# Altium schematic sheet ledbrick_pwm_top.SchDoc(ledbrick_pwm_top)
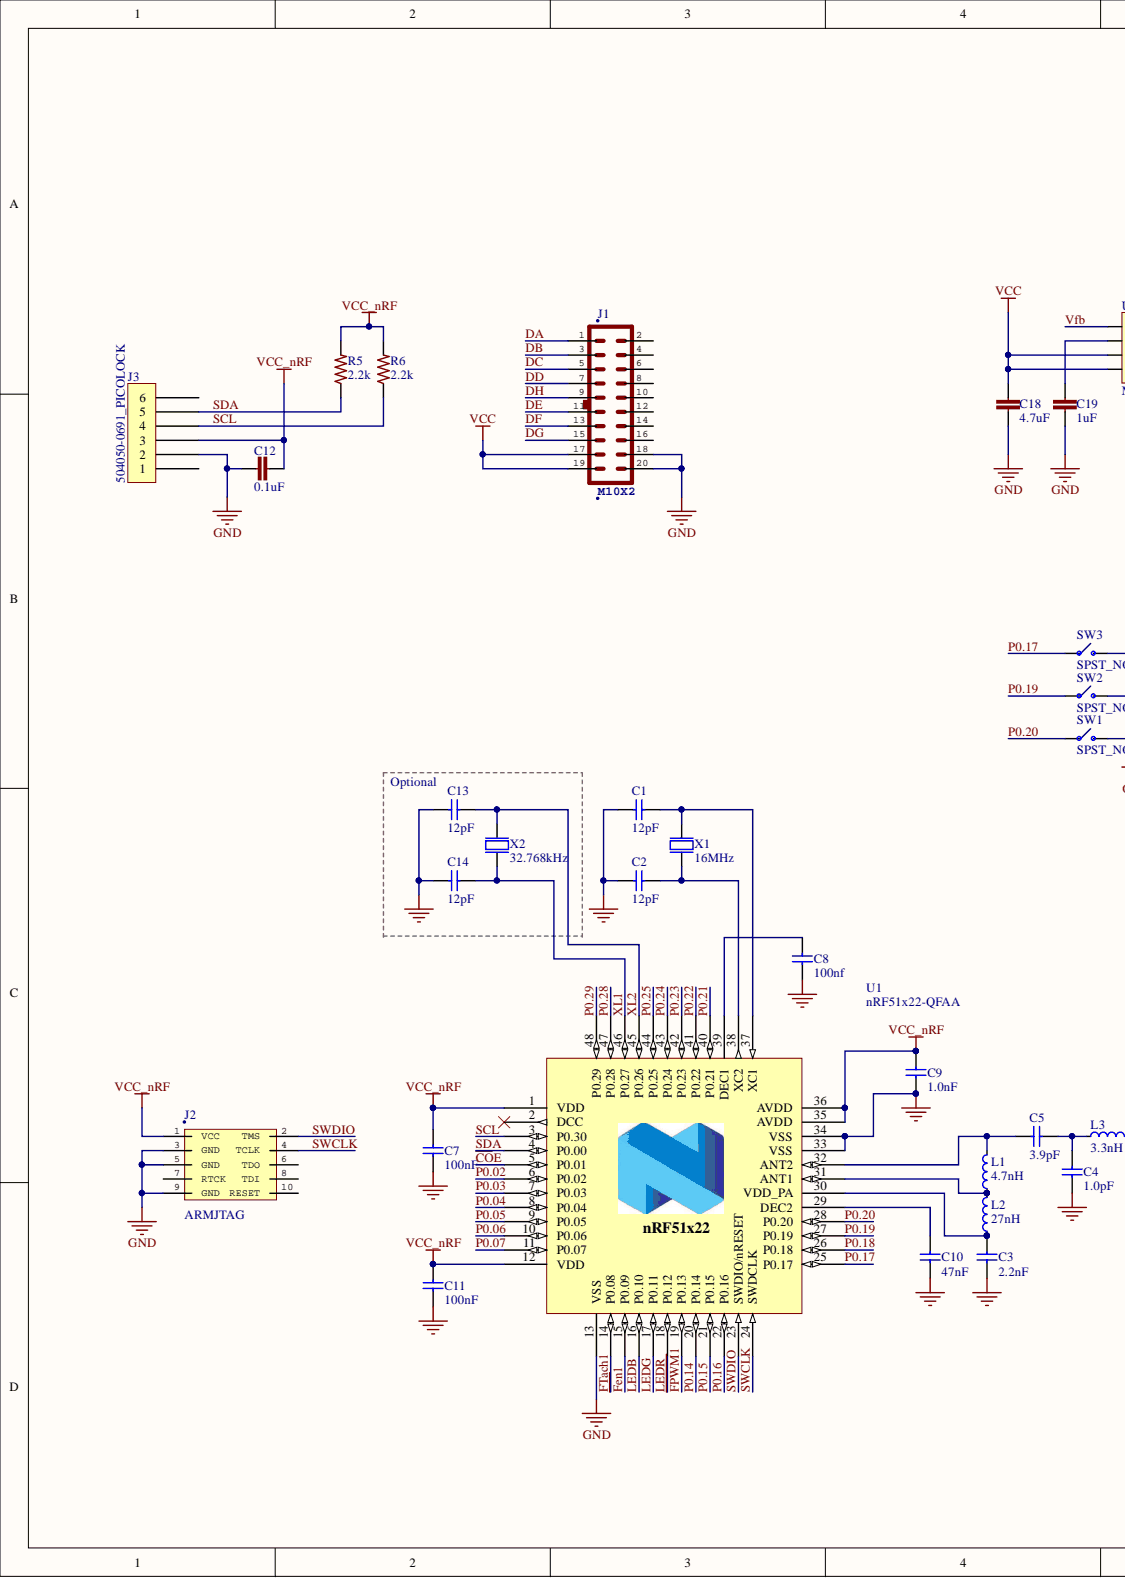
[diagram p0: ledbrick_pwm_top.SchDoc, left]
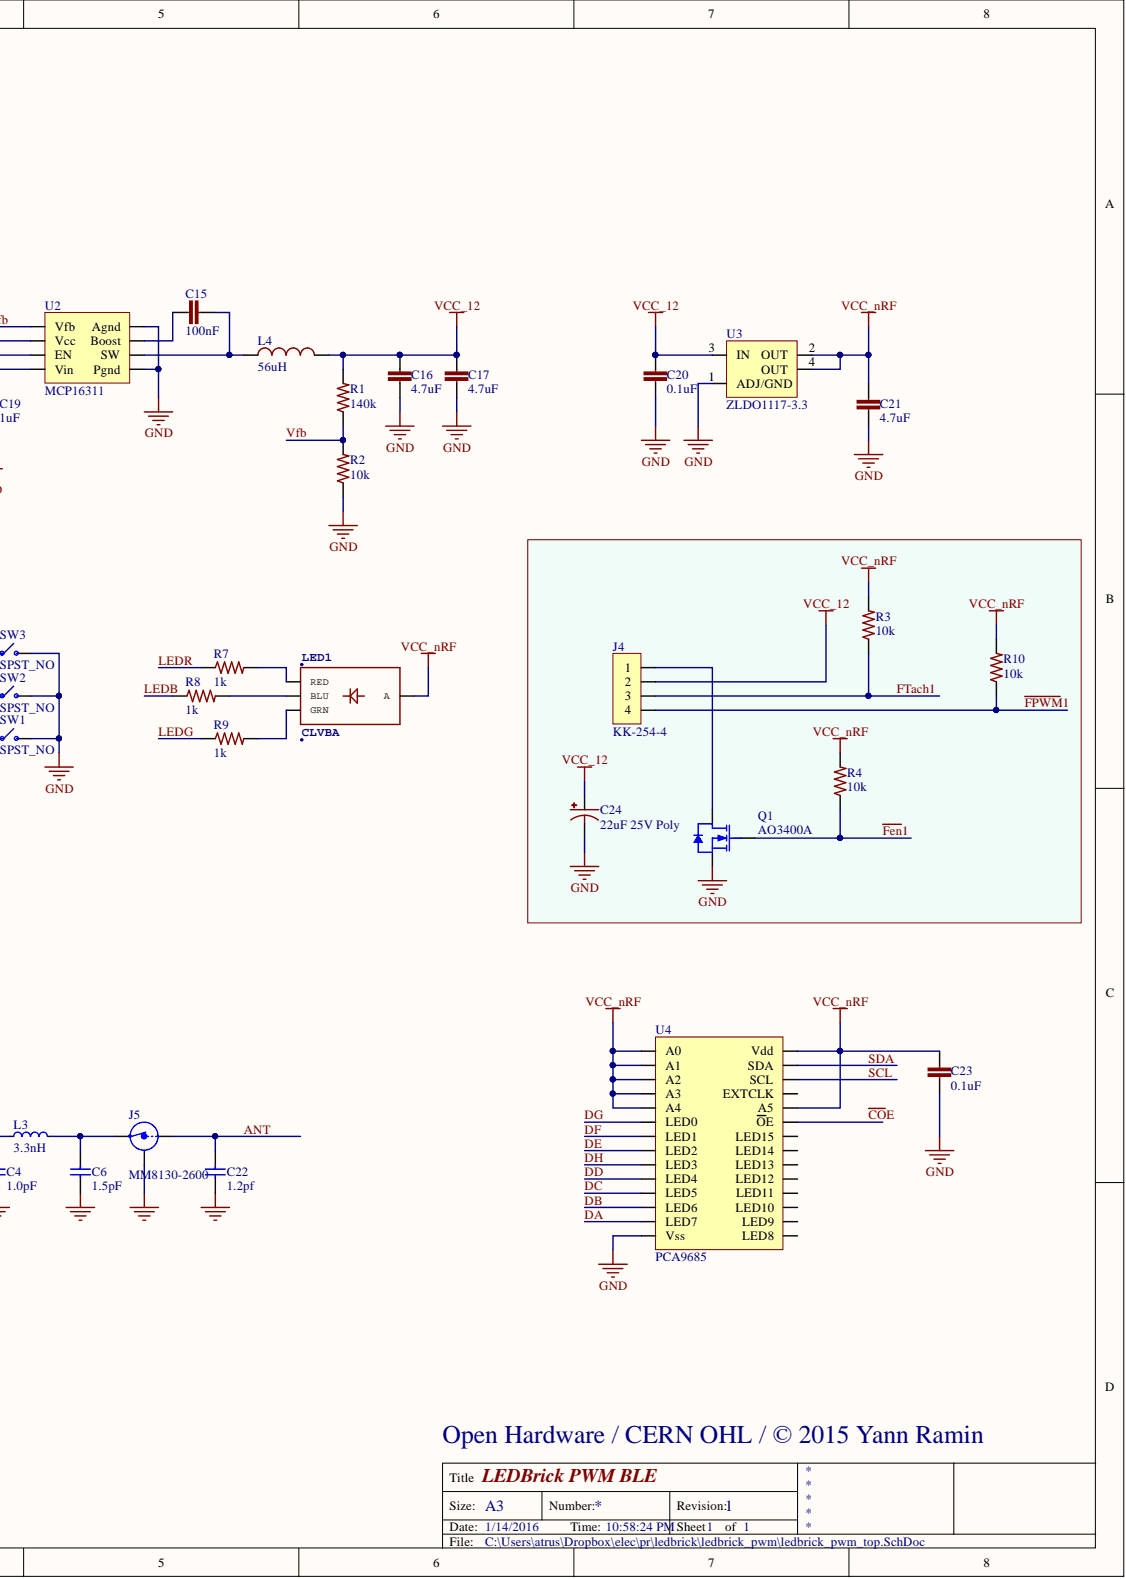
[diagram p1: ledbrick_pwm_top.SchDoc, right]

## Parts
C1  12pF  [CAPC1005X04L]
C2  12pF  [CAPC1005X04L]
C3  2.2nF  [CAPC1005X04L]
C4  1.0pF  [CAPC1005X04L]
C5  3.9pF  [CAPC1005X04L]
C6  1.5pF  [CAPC1005X04L]
C7  100nF  [CAPC1005X04L]
C8  100nf  [CAPC1005X04L]
C9  1.0nF  [CAPC1005X04L]
C10  47nF  [CAPC1005X04L]
C11  100nF  [CAPC1005X04L]
C12  0.1uF  [C0603]
C13  12pF  [CAPC1005X04L]
C14  12pF  [CAPC1005X04L]
C15  100nF  [C0603]
C16  4.7uF  [C1210]
C17  4.7uF  [C1210]
C18  4.7uF  [C1210]
C19  1uF  [C0603]
C20  0.1uF  [C0603]
C21  4.7uF  [C1210]
C22  1.2pf  [CAPC1005X04L]
C23  0.1uF  [C0603]
C24  22uF 25V Poly  [CT7343]
J1  M10X2  [2X10]
J2  ARMJTAG  [2X05_SMD]
J3  504050-0691_PICOLOCK  [504050-0691_PICOLOCK]
J4  KK-254-4  [KK-254-47053-3000]
J5  MM8130-2600  [COAXIAL-SWF]
L1  4.7nH  [INDC1005X04L]
L2  27nH  [INDC1005X04L]
L3  3.3nH  [INDC1005X04L]
L4  56uH  [SDR0302]
LED1  CLVBA  [LED-TRICOLOR-SMD]
Q1  AO3400A  [SOT23-3]
R1  140k  [R0603]
R2  10k  [R0603]
R3  10k  [R0603]
R4  10k  [R0603]
R5  2.2k  [R0603]
R6  2.2k  [R0603]
R7  1k  [R0603]
R8  1k  [R0603]
R9  1k  [R0603]
R10  10k  [R0603]
SW1  SPST_NO  [B3U-1000P]
SW2  SPST_NO  [B3U-1000P]
SW3  SPST_NO  [B3U-1000P]
U1  nRF51x22-QFAA  [QFN40P600X600X90-48N]
U2  MCP16311  [MSOP8]
U3  ZLDO1117-3.3  [SOT223]
U4  PCA9685  [TSSOP28]
X1  16MHz  [BT-XTAL_2520]
X2  32.768kHz  [XTAL_3215]

## Nets
GND: C1.2 C2.2 C3.2 C4.2 C6.2 C7.2 C8.2 C9.2 C10.2 C11.2 C12.1 C13.1 C14.1 C16.2 C17.2 C18.2 C19.2 C20.1 C21.2 C22.2 C23.1 C24.- J1.18 J1.20 J2.3(GND) J2.5(GND) J2.9(GND) J3.2 J5.2 Q1.3 R2.1 SW1.1 SW2.1 SW3.1 U1.13(VSS) U1.33(VSS) U1.34(VSS) U1.49 U2.5(Pgnd) U2.8(Agnd) U3.1(ADJ/GND) U4.14(Vss) X1.2 X1.4
VCC: C18.1 J1.17 J1.19 U2.3(EN) U2.4(Vin)
VCC_12: C16.1 C17.1 C20.2 C24.+ J4.2 L4.2 R1.2 U3.3(IN)
VCC_NRF: C7.1 C9.1 C11.1 C12.2 C21.1 C23.2 J2.1(VCC) J3.3 LED1.A R3.2 R4.2 R5.2 R6.2 R10.2 U1.1(VDD) U1.12(VDD) U1.35(AVDD) U1.36(AVDD) U3.2(OUT) U3.4(OUT) U4.1(A0) U4.2(A1) U4.3(A2) U4.4(A3) U4.5(A4) U4.24(A5) U4.28(Vdd)
~{CO}E: U1.5(P0.01) U4.23(OE)
~{FEN}1: Q1.1 R4.1 U1.15(P0.09)
~{FPWM}1: J4.4 R10.1 U1.19(P0.13)
ANT: C22.1 J5.1
DA: J1.1 U4.13(LED7)
DB: J1.3 U4.12(LED6)
DC: J1.5 U4.11(LED5)
DD: J1.7 U4.10(LED4)
DE: J1.11 U4.8(LED2)
DF: J1.13 U4.7(LED1)
DG: J1.15 U4.6(LED0)
DH: J1.9 U4.9(LED3)
FTACH1: J4.3 R3.1 U1.14(P0.08)
LEDB: R8.1 U1.16(P0.10)
LEDG: R9.1 U1.17(P0.11)
LEDR: R7.1 U1.18(P0.12)
P0.02: U1.6(P0.02)
P0.03: U1.7(P0.03)
P0.04: U1.8(P0.04)
P0.05: U1.9(P0.05)
P0.06: U1.10(P0.06)
P0.07: U1.11(P0.07)
P0.14: U1.20(P0.14)
P0.15: U1.21(P0.15)
P0.16: U1.22(P0.16)
P0.17: SW3.2 U1.25(P0.17)
P0.18: U1.26(P0.18)
P0.19: SW2.2 U1.27(P0.19)
P0.20: SW1.2 U1.28(P0.20)
P0.21: U1.40(P0.21)
P0.22: U1.41(P0.22)
P0.23: U1.42(P0.23)
P0.24: U1.43(P0.24)
P0.25: U1.44(P0.25)
P0.28: U1.47(P0.28)
P0.29: U1.48(P0.29)
SCL: J3.4 R6.1 U1.3(P0.30) U4.26(SCL)
SDA: J3.5 R5.1 U1.4(P0.00) U4.27(SDA)
SWCLK: J2.4(TCLK) U1.24(SWDCLK)
SWDIO: J2.2(TMS) U1.23(SWDIO/nRESET)
VFB: R1.1 R2.2 U2.1(Vfb)
XL1: C14.2 U1.46(P0.27) X2.2
XL2: C13.2 U1.45(P0.26) X2.1
NetC1_1: C1.1 U1.37(XC1) X1.1
NetC2_1: C2.1 U1.38(XC2) X1.3
NetC3_1: C3.1 L2.1 U1.30(VDD_PA)
NetC4_1: C4.1 C5.2 L3.1
NetC5_1: C5.1 L1.2 U1.32(ANT2)
NetC6_1: C6.1 J5.3 L3.2
NetC8_1: C8.1 U1.39(DEC1)
NetC10_1: C10.1 U1.29(DEC2)
NetC15_1: C15.1 U2.7(Boost)
NetC15_2: C15.2 L4.1 U2.6(SW)
NetC19_1: C19.1 U2.2(Vcc)
NetJ4_1: J4.1 Q1.2
NetL1_1: L1.1 L2.2 U1.31(ANT1)
NetLED1_B: LED1.B(BLU) R8.2
NetLED1_G: LED1.G(GRN) R9.2
NetLED1_R: LED1.R(RED) R7.2

Not connected: J1 2 4 6 8 10 12 14 16; J2 6(TDO) 7(RTCK) 8(TDI) 10(RESET); J3 1 6; U1 2(DCC); U4 15(LED8) 16(LED9) 17(LED10) 18(LED11) 19(LED12) 20(LED13) 21(LED14) 22(LED15) 25(EXTCLK)

## Library data of the parts
C1-C11 C13 C14 C22 = CAP_0402: Capacitor, NP0, ±2%; Part Number: C0402-12p; Artikkelnr Noca: 20029159; Artikkelnr Simpro: N.A.; Note: 50V; Component Kind: Standard; Footprint Doc: 0402; LatestRevisionDate: 2011-05-11; Pin Count: 2; Published: 2009-05-08; Library Name: nrf51x22_qfax.IntLib; Publisher: Nordic Semiconductor
  C1 C2 C13 C14: Value: 12pF
  C3: Value: 2.2nF
  C4: Value: 1.0pF
  C5: Value: 3.9pF
  C6: Value: 1.5pF
  C7 C11: Value: 100nF
  C8: Value: 100nf
  C9: Value: 1.0nF
  C10: Value: 47nF
  C22: Value: 1.2pf
C12 C15-C21 C23 = C-EU: Component Kind: Standard; Library Name: rcl.IntLib
C24 = CPOL-US: Component Kind: Standard; Library Name: rcl.IntLib
J2 = ARM_MINI_10PIN_JTAG: Component Kind: Standard; Library Name: connectors.IntLib
J5 = Coaxial-Internal-SW: Coaxial Connector with Switch; 2nd source part number: 81-MM8130-2600RA2; Manufacturer: Murata; Artikkelnr Noca: 55029011; Artikkelnr Simpro: N.A.; 2nd source Supplier: Mouser; Component Kind: Standard; Datasheet: http://www.murata.com/products/catalog/pdf/o33e.pdf; Footprint Doc: COAXIAL-SWF; LatestRevisionDate: 2014-04-24; Library Name: pca10028.IntLib; Manufacturer Part Number: MM8130-2600; Part Number: MM8130-2600; Pin Count: 3; Published: 2014-04-24; Supplier: Digi-Key; Supplier part number: 490-4981-1-ND; Value: MM8130-2600; Publisher: Nordic Semiconductor
L1-L3 = IND_0402: High frequency chip inductor ±10%; 2nd source part number: 658-1328; Manufacturer: Taiyo Yuden; Manufacturer Part Number: HK100515NJ-T; Part Number: L0402-15n; Artikkelnr Noca: 33029323; Artikkelnr Simpro: N.A.; 2nd source Supplier: Farnell; Component Kind: Standard; Datasheet: http://www.yuden.co.jp/productdata/catalog/en/mlci04_e.pdf; Footprint Doc: 0402; LatestRevisionDate: 2012-09-19; Note: High frequency chip inductor ±10%; Pin Count: 2; Published: 2010-07-12; Supplier: Digi-Key; Supplier part number: 587-1521-1-ND; Library Name: nrf51x22_qfax.IntLib; Publisher: Nordic Semiconductor
  L1: Value: 4.7nH
  L2: Value: 27nH
  L3: Value: 3.3nH
L4 = L-US: Component Kind: Standard; Library Name: rcl.IntLib
LED1 = LED-TRICOLOR: Component Kind: Standard; Library Name: general.IntLib
Q1 = NFET: Component Kind: Standard; Library Name: general.IntLib
R1-R10 = R-US: Component Kind: Standard; Library Name: rcl.IntLib
U1 = nRF51x22_QFAx: Multi-protocol Bluetooth Low Energy, ANT and 2.4GHz proprietary system-on-chip; Manufacturer: Nordic Semiconductor; Artikkelnr Noca: N.A.; Note: nRF51422 - QFN48 6x6 0.4mm pitch; Artikkelnr Simpro: N.A.; Component Kind: Standard; Footprint Doc: QFN-48; LatestRevisionDate: 2014-09-24; Library Name: nrf51x22_qfax.IntLib; Manufacturer Part Number: nRF51x22-QFAA; Part Number: nRF51x22-QFAA; Pin Count: 48; Published: 2014-09-24; Supplier: Nordic Semiconductor; Value: nRF51x22-QFAA; Publisher: Nordic Semiconductor
U3 = V_REG_LM1117SOT223: Component Kind: Standard; Library Name: general.IntLib
X1 = XTAL_4-pin_grounded: XTAL SMD 2520, 16MHz, 8pF, ±40ppm; Manufacturer: NDK; Manufacturer Part Number: NX2520SA-16MHZ-STD-CSW-5; Artikkelnr Noca: 22019192; Artikkelnr Simpro: N.A.; Note: XTAL SMD 16.000MHz; Component Kind: Standard; Datasheet: http://www.ndk.com/images/products/catalog/c_NX2520SA-STD-CSW-5_e.pdf; Footprint Doc: BT-XTAL_2520; LatestRevisionDate: 2012-06-15; Part Number: NX2520SA-16MHZ-STD-CSW-5; Pin Count: 4; Published: 2012-06-15; Supplier: Digi-Key; Supplier part number: 644-1169-1-ND; Value: 16MHz; Library Name: nrf51x22_qfax.IntLib; Publisher: Nordic Semiconductor
X2 = XTAL_2-pin: XTAL SMD 3215, 32.768kHz, 9pF, ±20ppm; 2nd source part number: 127-8037; Manufacturer: Epson Toyocom; Manufacturer Part Number: FC-135 32.768KHZ ±20PPM,9.0PF; Part Number: XTAL_FC-135 32.768k; Artikkelnr Noca: 22019091; Artikkelnr Simpro: N.A.; Note: XTAL SMD 32.768kHz, 3215; 2nd source Supplier: Farnell; Component Kind: Standard; Datasheet: http://www.farnell.com/datasheets/57480.pdf; Footprint Doc: XTAL_3215; LatestRevisionDate: 2014-03-27; Pin Count: 2; Published: 2010-10-18; Supplier: Digi-Key; Supplier part number: FC-135 32.7680KA-AC-ND; Value: 32.768kHz; Library Name: nrf51x22_qfax.IntLib; Publisher: Nordic Semiconductor
J1 = M10X2: Component Kind: Standard; Library Name: connectors.IntLib
J3 = 504050-0691_PICOLOCK: Component Kind: Standard; Library Name: connectors.IntLib
J4 = KK-254-4: Component Kind: Standard; Library Name: connectors.IntLib
SW1-SW3 = SPST_NO: Component Kind: Standard; Library Name: switches.IntLib
U2 = MCP16311: Component Kind: Standard; Library Name: general.IntLib
U4 = PCA9685: Component Kind: Standard; Library Name: general.IntLib
